# Altium schematic sheet PFM_connectors.SchDoc(PFM_connectors)
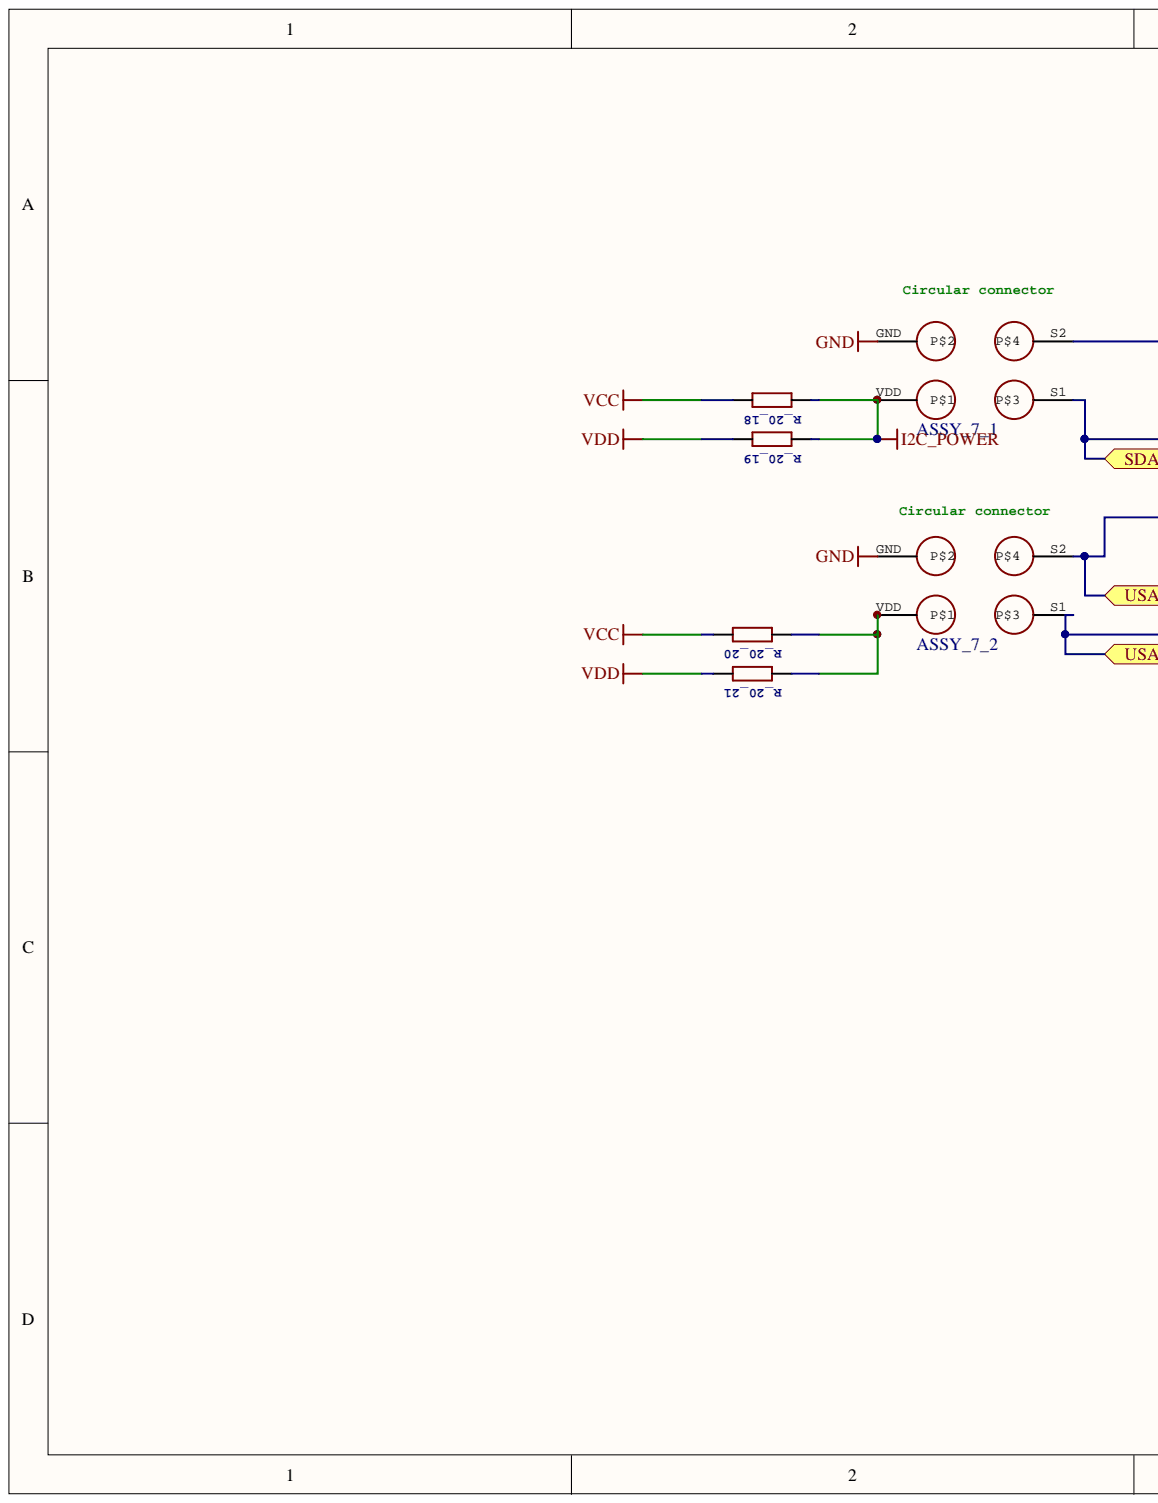
[diagram p0: PFM_connectors.SchDoc, left]
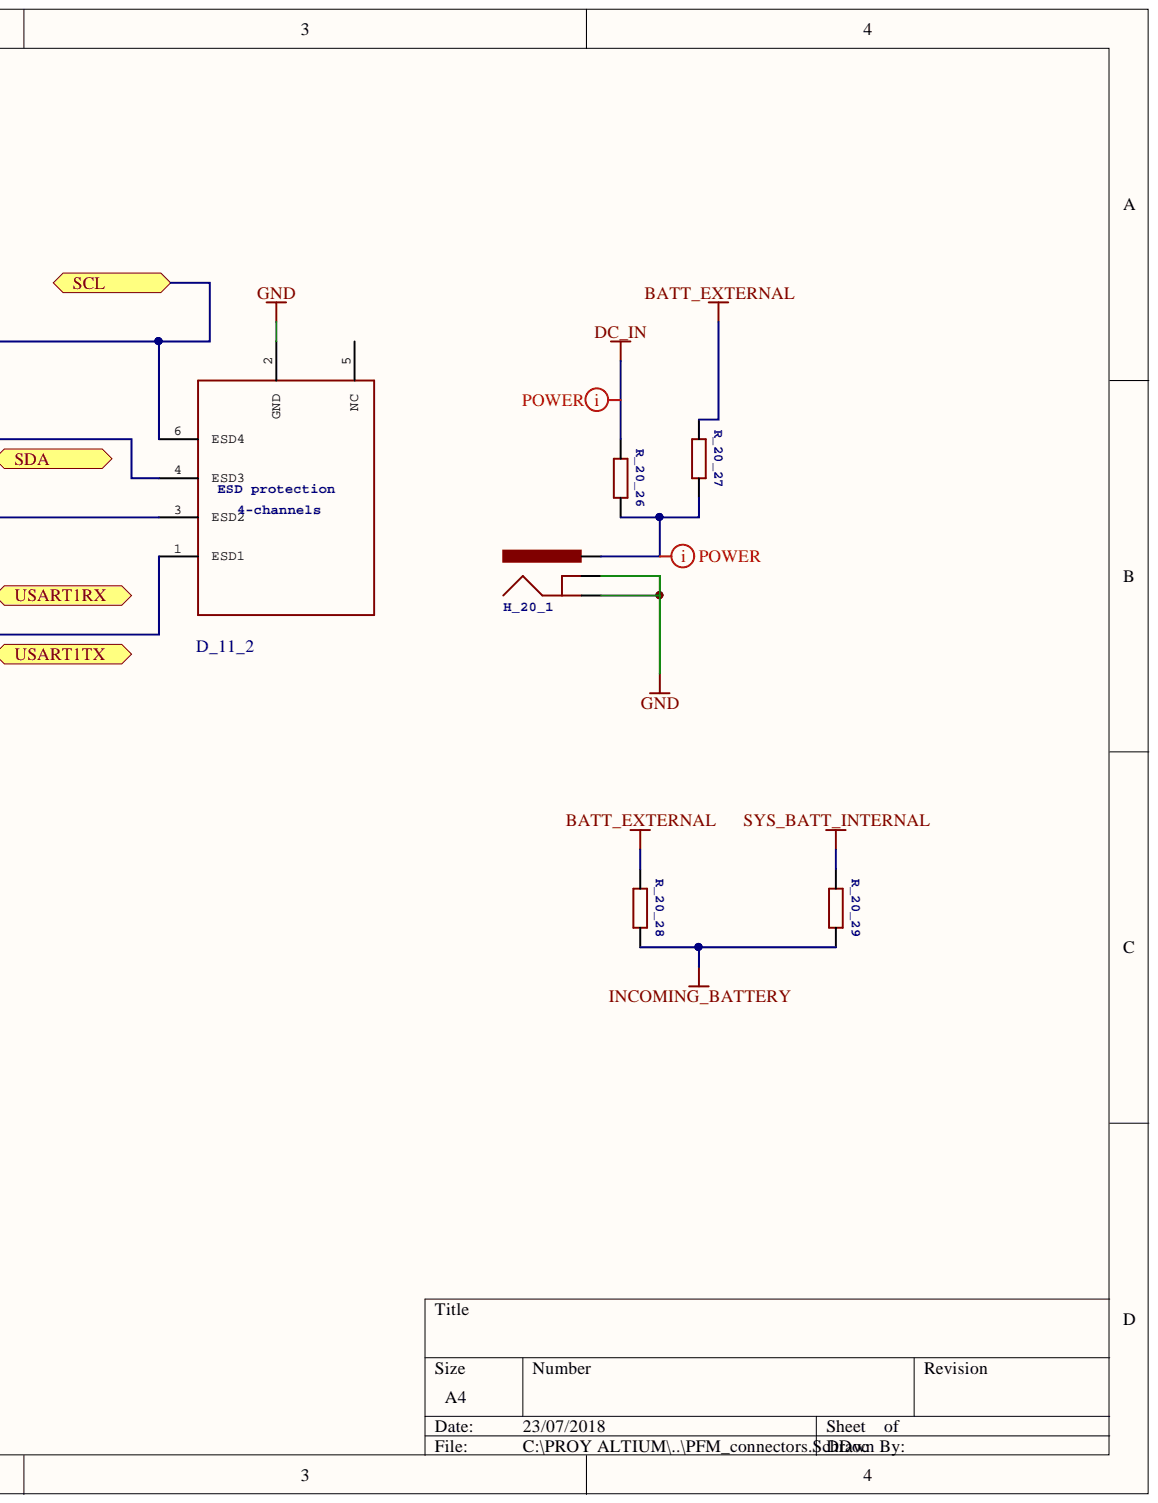
[diagram p1: PFM_connectors.SchDoc, right]

## Parts
ASSY_7_1  RKT 4-225/2 M;Celtronik  [CONN_4POS_PCK]  (value not drawn)
ASSY_7_2  RKT 4-225/2 M;Celtronik  [CONN_4POS_PCK]  (value not drawn)
D_11_2  ESDprotection: ESD protection  [PUSB2X4Y_BRD]  (hidden comment: PUSB2X4YH;Nexperia)
H_20_1  448-382;RS_pro  [POWER_JACK_PTH_BREAD]  (value not drawn)
R_20_18  0;ERJ3GEY0R00V;Panasonic;  [R0603]  (value not drawn)
R_20_19  0;ERJ3GEY0R00V;Panasonic;  [R0603]  (value not drawn)
R_20_20  0;ERJ3GEY0R00V;Panasonic;  [R0603]  (value not drawn)
R_20_21  0;ERJ3GEY0R00V;Panasonic;  [R0603]  (value not drawn)
R_20_26  0;ERJ3GEY0R00V;Panasonic;  [R0603]  (value not drawn)
R_20_27  0;ERJ3GEY0R00V;Panasonic;  [R0603]  (value not drawn)
R_20_28  0;ERJ3GEY0R00V;Panasonic;  [R0603]  (value not drawn)
R_20_29  0;ERJ3GEY0R00V;Panasonic;  [R0603]  (value not drawn)

## Ports
SCL, SDA, USART1RX, USART1TX

## Nets
BATT_EXTERNAL: R_20_27.1 R_20_28.1
DC_IN: R_20_26.1
GND: ASSY_7_1.GND(P$2) ASSY_7_2.GND(P$2) D_11_2.2(GND) H_20_1.GND H_20_1.GNDBREAK
I2C_POWER: ASSY_7_1.VDD(P$1) R_20_18.1 R_20_19.1
INCOMING_BATTERY: R_20_28.2 R_20_29.2
SYS_BATT_INTERNAL: R_20_29.1
VCC: R_20_18.2 R_20_20.2
VDD: R_20_19.2 R_20_21.2
SCL: ASSY_7_1.S2(P$4) D_11_2.6(ESD4) ; port SCL
SDA: ASSY_7_1.S1(P$3) D_11_2.4(ESD3) ; port SDA
USART1RX: ASSY_7_2.S2(P$4) D_11_2.3(ESD2) ; port USART1RX
USART1TX: ASSY_7_2.S1(P$3) D_11_2.1(ESD1) ; port USART1TX
NetASSY_7_2_VDD: ASSY_7_2.VDD(P$1) R_20_20.1 R_20_21.1
NetH_20_1_PWR: H_20_1.PWR R_20_26.2 R_20_27.2

Not connected: D_11_2 5(NC)

## Library data of the parts
ASSY_7_1 ASSY_7_2 = 4POS_DEV: Component Kind: Standard; Library Name: CONN_CIRCULAR_4POS.IntLib
D_11_2 = PUSB2X4Y_ENL: Library Name: ESD.IntLib; Component Kind: Standard; 4-channels: 4-channels
H_20_1 = POWER_JACKPTH_BREAD: Power JackThis is the standard 5.5mm barrel jack for power. The PTH is the most common, proven, reliable, footprint. The Slot footprint only works if the mill layer is transmitted to the PCB fab house so be warned. Mating wall wart : TOL-00298 (and others); PROD_ID: PRT-10811; Library Name: SparkFun-Connectors.IntLib; Component Kind: Standard
R_20_18-R_20_21 R_20_26-R_20_29 = R-EU_R0603: RESISTOR, European symbol; Library Name: PBv4p0.SCHLIB; Component Kind: Standard
